# Altium schematic sheet cpuledin.SchDoc(cpuledin)
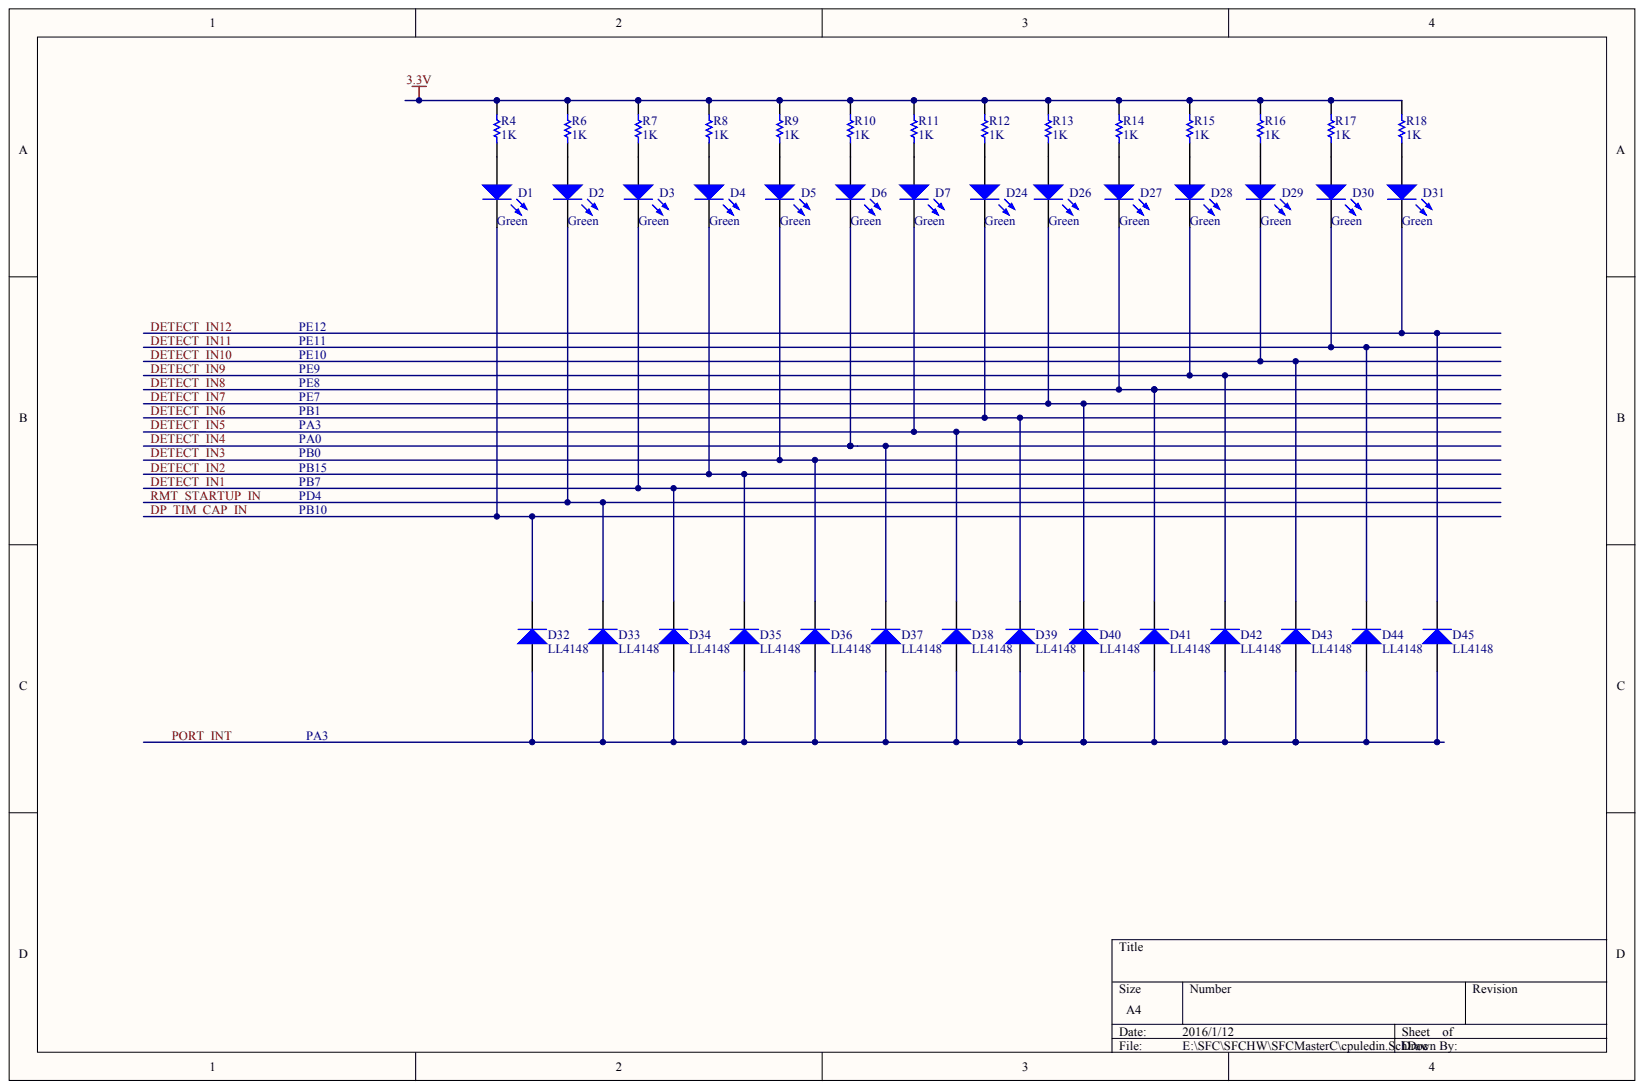
[diagram p0: cpuledin.SchDoc]

## Parts
D1  Green
D2  Green
D3  Green
D4  Green
D5  Green
D6  Green
D7  Green
D24  Green
D26  Green
D27  Green
D28  Green
D29  Green
D30  Green
D31  Green
D32  LL4148
D33  LL4148
D34  LL4148
D35  LL4148
D36  LL4148
D37  LL4148
D38  LL4148
D39  LL4148
D40  LL4148
D41  LL4148
D42  LL4148
D43  LL4148
D44  LL4148
D45  LL4148
R4  1K
R6  1K
R7  1K
R8  1K
R9  1K
R10  1K
R11  1K
R12  1K
R13  1K
R14  1K
R15  1K
R16  1K
R17  1K
R18  1K

## Nets
3.3V: R4.2 R6.2 R7.2 R8.2 R9.2 R10.2 R11.2 R12.2 R13.2 R14.2 R15.2 R16.2 R17.2 R18.2
DETECT_IN1: D3.2 D34.2
DETECT_IN2: D4.2 D35.2
DETECT_IN3: D5.2 D36.2
DETECT_IN4: D6.2 D37.2
DETECT_IN5: D7.2 D38.2
DETECT_IN6: D24.2 D39.2
DETECT_IN7: D26.2 D40.2
DETECT_IN8: D27.2 D41.2
DETECT_IN9: D28.2 D42.2
DETECT_IN10: D29.2 D43.2
DETECT_IN11: D30.2 D44.2
DETECT_IN12: D31.2 D45.2
DP_TIM_CAP_IN: D1.2 D32.2
PORT_INT: D32.1 D33.1 D34.1 D35.1 D36.1 D37.1 D38.1 D39.1 D40.1 D41.1 D42.1 D43.1 D44.1 D45.1
RMT_STARTUP_IN: D2.2 D33.2
NetD1_1: D1.1 R4.1
NetD2_1: D2.1 R6.1
NetD3_1: D3.1 R7.1
NetD4_1: D4.1 R8.1
NetD5_1: D5.1 R9.1
NetD6_1: D6.1 R10.1
NetD7_1: D7.1 R11.1
NetD24_1: D24.1 R12.1
NetD26_1: D26.1 R13.1
NetD27_1: D27.1 R14.1
NetD28_1: D28.1 R15.1
NetD29_1: D29.1 R16.1
NetD30_1: D30.1 R17.1
NetD31_1: D31.1 R18.1

## Library data of the parts
R4 R6-R18 = (no symbol name): Value: 10K; Published: 8-Jun-2000; LatestRevisionDate: 17-Jul-2002; LatestRevisionNote: Re-released for DXP Platform.; PackageReference: AXIAL-0.3; Publisher: Altium Limited
D1-D7 D24 D26-D45 = (no symbol name): Published: 8-Jun-2000; Publisher: Altium Limited
  D1-D7 D24 D26-D31: LatestRevisionDate: 08-Jul-2005; LatestRevisionNote: Mech Layer 1 removed.; PackageReference: LED-1
  D32-D45: LatestRevisionDate: 17-Jul-2002; LatestRevisionNote: Re-released for DXP Platform.; DatasheetVersion: 1998; PackageVersion: Aug-1999; PackageReference: DO-41; Code_JEDEC: DO-204-AL; ComponentLink1Description: Manufacturer Link; ComponentLink1URL: http://www.fairchildsemi.com/; ComponentLink2Description: Datasheet; ComponentLink2URL: http://www.fairchildsemi.com/ds/1N%2F1N4001.pdf
